# Altium schematic sheet Coheat_Thermostat.SchDoc
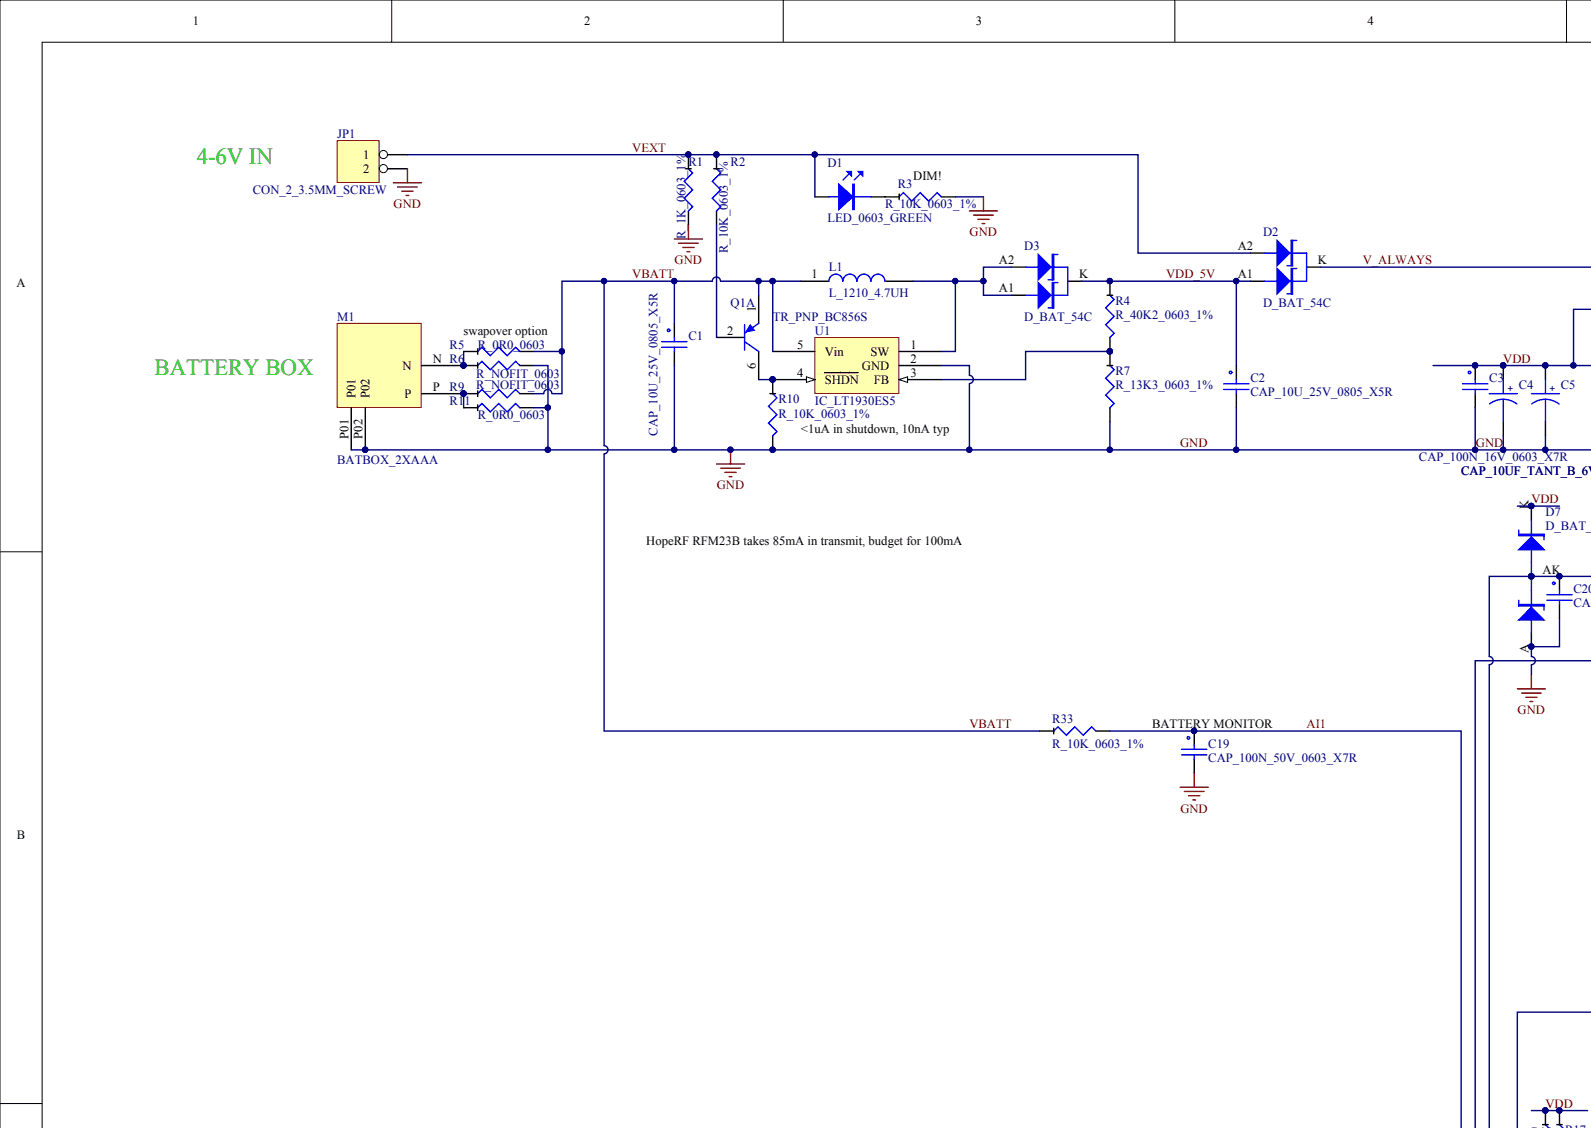
[diagram p0: Coheat_Thermostat.SchDoc, top left]
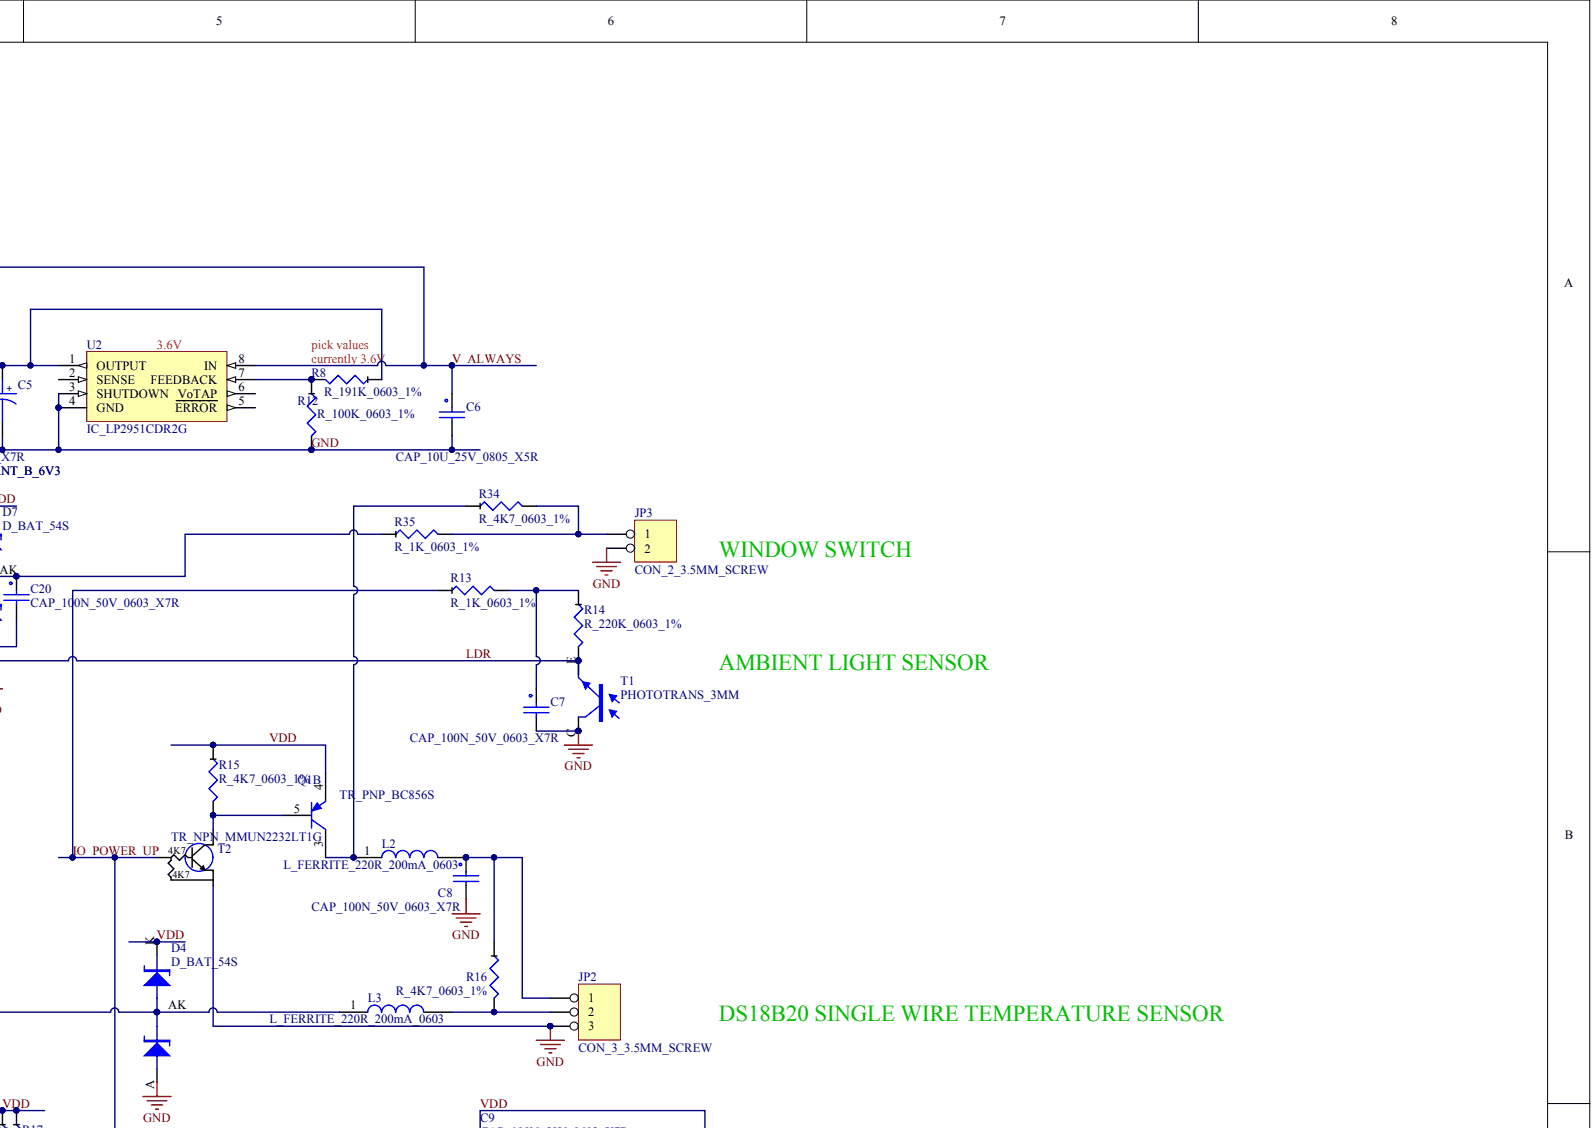
[diagram p1: Coheat_Thermostat.SchDoc, top right]
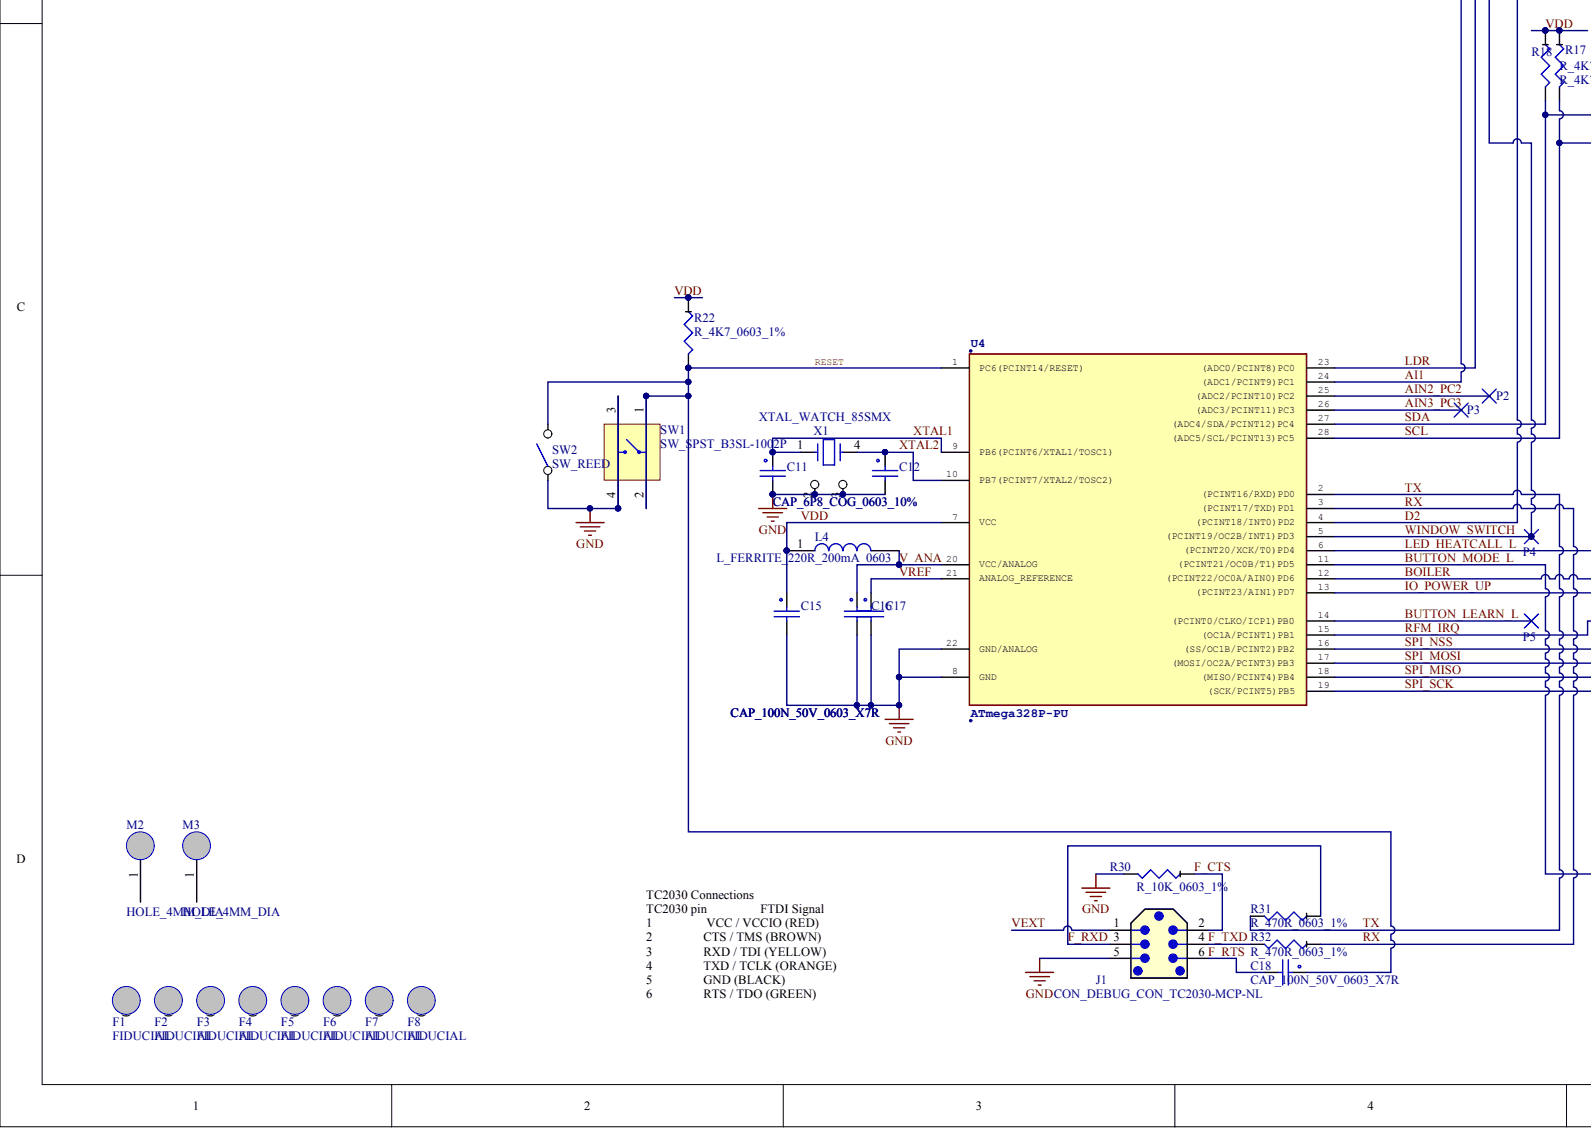
[diagram p2: Coheat_Thermostat.SchDoc, bottom left]
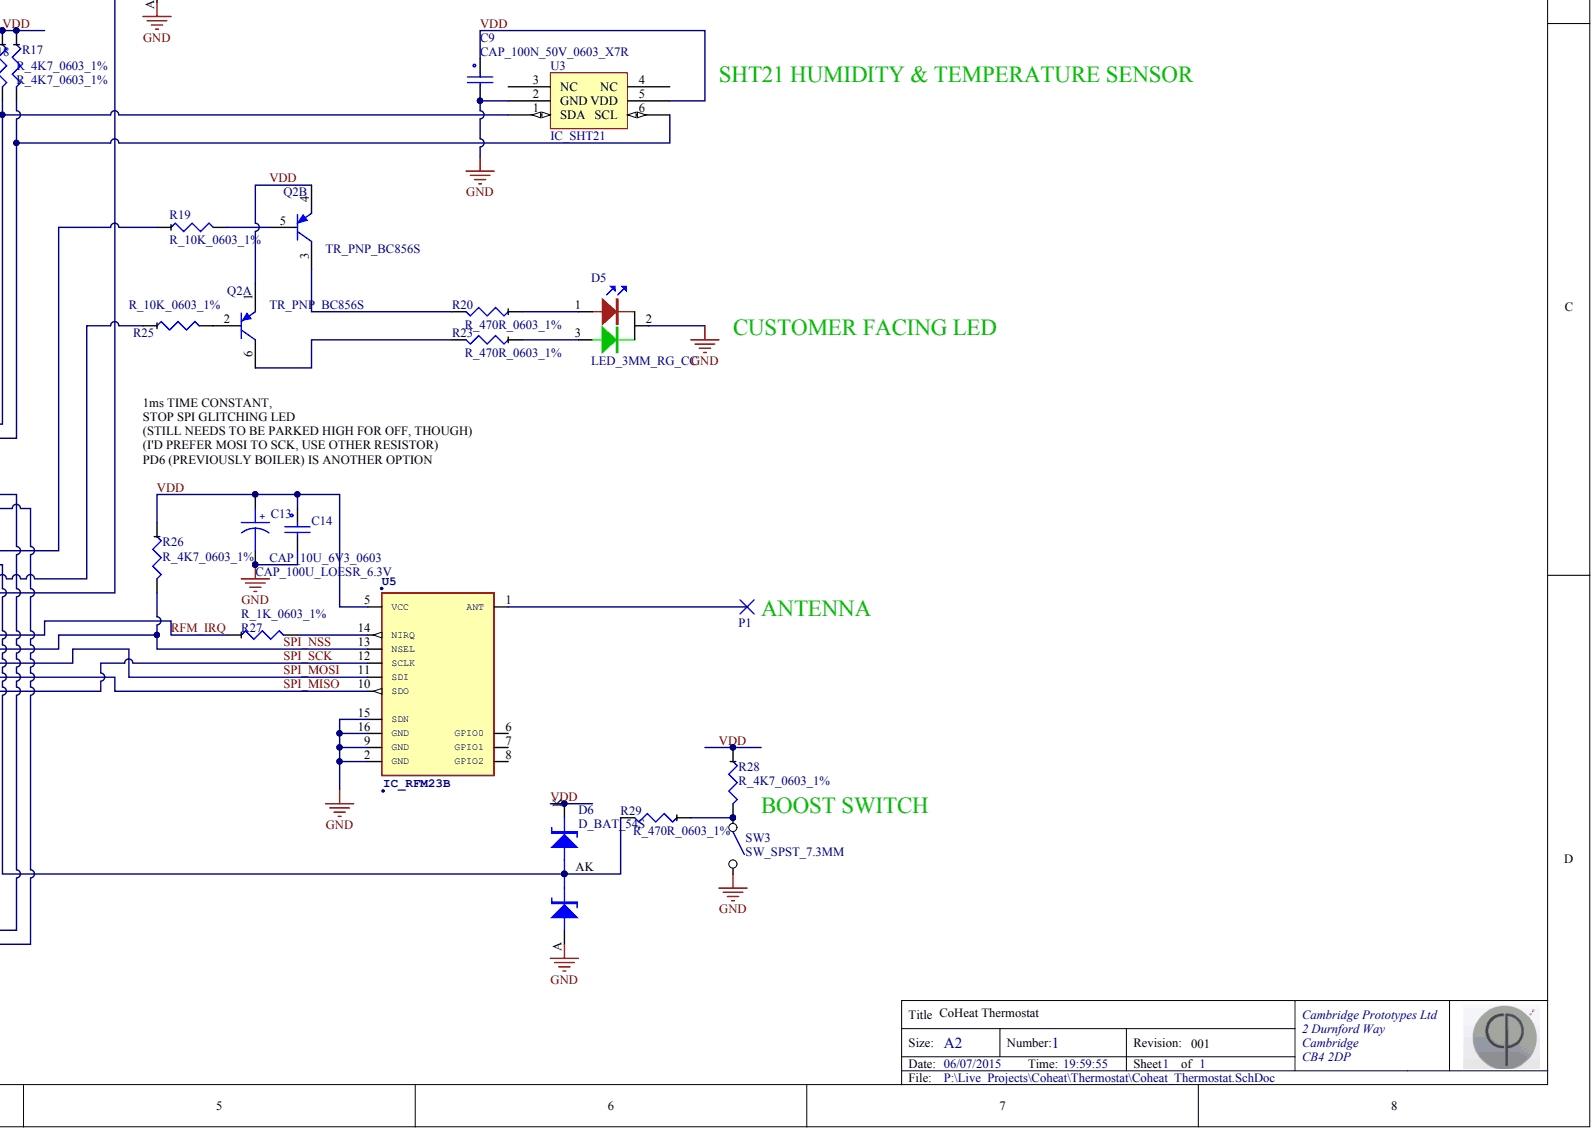
[diagram p3: Coheat_Thermostat.SchDoc, bottom right]

## Parts
C1  CAP_10U_25V_0805_X5R  [C_0805]
C2  CAP_10U_25V_0805_X5R  [C_0805]
C3  CAP_100N_16V_0603_X7R  [C_0603]
C4  CAP_10UF_TANT_B_6V3  [TANT_B]
C5  CAP_10UF_TANT_B_6V3  [TANT_B]
C6  CAP_10U_25V_0805_X5R  [C_0805]
C7  CAP_100N_50V_0603_X7R  [C_0603]
C8  CAP_100N_50V_0603_X7R  [C_0603]
C9  CAP_100N_50V_0603_X7R  [C_0603]
C11  CAP_6P8_COG_0603_10%  [C_0603]
C12  CAP_6P8_COG_0603_10%  [C_0603]
C13  CAP_100U_LOESR_6.3V  [C_2917_1.9MM]
C14  CAP_10U_6V3_0603  [C_0603]
C15  CAP_100N_50V_0603_X7R  [C_0603]
C16  CAP_100N_50V_0603_X7R  [C_0603]
C17  CAP_100N_50V_0603_X7R  [C_0603]
C18  CAP_100N_50V_0603_X7R  [C_0603]
C19  CAP_100N_50V_0603_X7R  [C_0603]
C20  CAP_100N_50V_0603_X7R  [C_0603]
D1  LED_0603_GREEN  [LED_0603_GREEN]
D2  D_BAT_54C  [SOT23_BAT54C]
D3  D_BAT_54C  [SOT23_BAT54C]
D4  D_BAT_54S  [SOT23_BAT54S]
D5  LED_3MM_RG_CC  [LED_3MM_RG_CC]
D6  D_BAT_54S  [SOT23_BAT54S]
D7  D_BAT_54S  [SOT23_BAT54S]
F1  FIDUCIAL  [FIDUCIAL_OV]
F2  FIDUCIAL  [FIDUCIAL_OV]
F3  FIDUCIAL  [FIDUCIAL_OV]
F4  FIDUCIAL  [FIDUCIAL_OV]
F5  FIDUCIAL  [FIDUCIAL_OV]
F6  FIDUCIAL  [FIDUCIAL_OV]
F7  FIDUCIAL  [FIDUCIAL_OV]
F8  FIDUCIAL  [FIDUCIAL_OV]
J1  CON_DEBUG_CON_TC2030-MCP-NL  [CON_TC2030-MCP-NL]  (value not drawn)  (no BOM)
JP1  CON_2_3.5MM_SCREW  [CON_CTB0305/2]
JP2  CON_3_3.5MM_SCREW  [CON_CTB0305/3]
JP3  CON_2_3.5MM_SCREW  [CON_CTB0305/2]
L1  L_1210_4.7UH  [L_1210]
L2  L_FERRITE_220R_200mA_0603  [L_0603]
L3  L_FERRITE_220R_200mA_0603  [L_0603]
L4  L_FERRITE_220R_200mA_0603  [L_0603]
M1  BATBOX_2XAAA  [BATBOX_2AAA]
M2  HOLE_4MM_DIA  [HOLE_4MM_DIA]  (no BOM)
M3  HOLE_4MM_DIA  [HOLE_4MM_DIA]  (no BOM)
P1  TP  [TPA_2MM]  (value not drawn)  (no BOM)
P2  TP  [TPT]  (value not drawn)  (no BOM)
P3  TP  [TPT]  (value not drawn)  (no BOM)
P4  TP  [TPT]  (value not drawn)  (no BOM)
P5  TP  [TPT]  (value not drawn)  (no BOM)
Q1  TR_PNP_BC856S  [SOT363_N]  (parts A,B)
Q2  TR_PNP_BC856S  [SOT363_N]  (parts A,B)
R1  R_1K_0603_1%  [R_0603]
R2  R_10K_0603_1%  [R_0603]
R3  R_10K_0603_1%  [R_0603]
R4  R_40K2_0603_1%  [R_0603]
R5  R_0R0_0603  [R_0603]
R6  R_NOFIT_0603  [R_0603]
R7  R_13K3_0603_1%  [R_0603]
R8  R_191K_0603_1%  [R_0603]
R9  R_NOFIT_0603  [R_0603]
R10  R_10K_0603_1%  [R_0603]
R11  R_0R0_0603  [R_0603]
R12  R_100K_0603_1%  [R_0603]
R13  R_1K_0603_1%  [R_0603]
R14  R_220K_0603_1%  [R_0603]
R15  R_4K7_0603_1%  [R_0603]
R16  R_4K7_0603_1%  [R_0603]
R17  R_4K7_0603_1%  [R_0603]
R18  R_4K7_0603_1%  [R_0603]
R19  R_10K_0603_1%  [R_0603]
R20  R_470R_0603_1%  [R_0603]
R22  R_4K7_0603_1%  [R_0603]
R23  R_470R_0603_1%  [R_0603]
R25  R_10K_0603_1%  [R_0603]
R26  R_4K7_0603_1%  [R_0603]
R27  R_1K_0603_1%  [R_0603]
R28  R_4K7_0603_1%  [R_0603]
R29  R_470R_0603_1%  [R_0603]
R30  R_10K_0603_1%  [R_0603]
R31  R_470R_0603_1%  [R_0603]
R32  R_470R_0603_1%  [R_0603]
R33  R_10K_0603_1%  [R_0603]
R34  R_4K7_0603_1%  [R_0603]
R35  R_1K_0603_1%  [R_0603]
SW1  SW_SPST_B3SL-1002P  [SW_B3SL-1002P]
SW2  SW_REED  [SW_REED_MN2308]
SW3  SW_SPST_7.3MM  [SW_MCDTS2-4N]
T1  PHOTOTRANS_3MM  [PD_3MM]
T2  TR_NPN_MMUN2232LT1G  [SOT23_NPN_BC846]
U1  IC_LT1930ES5  [SOT23_5_BASE]
U2  IC_LP2951CDR2G  [IC_SO8]
U3  IC_SHT21  PART: SHT21  [IC_DFN6_HTU21D]
U4  ATmega328P-PU  [IC_DIP28_SOCKETED]
U5  IC_RFM23B  [IC_RFM23-XXX-S1]
X1  XTAL_WATCH_85SMX  [XTAL_86SMX]

## Nets
AI1: C19.1 R33.2 U4.24((ADC1/PCINT9)PC1)
AIN2_PC2: P2.1 U4.25((ADC2/PCINT10)PC2)
AIN3_PC3: P3.1 U4.26((ADC3/PCINT11)PC3)
BOILER: R25.1 U4.12((PCINT22/OC0A/AIN0)PD6)
BUTTON_LEARN_L: P5.1 U4.14((PCINT0/CLKO/ICP1)PB0)
BUTTON_MODE_L: D6.AK R29.2 U4.11((PCINT21/OC0B/T1)PD5)
D2: D4.AK L3.1 U4.4((PCINT18/INT0)PD2)
F_CTS: J1.2 R30.1
F_RTS: C18.2 J1.6
F_RXD: J1.3 R31.1
F_TXD: J1.4 R32.2
GND: C1.2 C2.2 C3.2 C4.N C5.N C6.2 C7.2 C8.2 C9.2 C11.2 C12.2 C13.N C14.2 C15.2 C16.2 C17.2 C19.2 C20.2 D4.A D5.2 D6.A D7.A J1.5 JP1.2 JP2.3 JP3.2 M1.P01 M1.P02 R1.2 R3.2 R6.2 R7.2 R10.2 R11.2 R12.2 R30.2 SW1.3 SW1.4 SW2.1 SW3.2 T1.C T2.E U1.2(GND) U2.3(SHUTDOWN) U2.4(GND) U3.2(GND) U4.8(GND) U4.22(GND/ANALOG) U5.2(GND) U5.9(GND) U5.15(SDN) U5.16(GND) X1.2 X1.3
IO_POWER_UP: R13.1 T2.B U4.13((PCINT23/AIN1)PD7)
LDR: R14.2 T1.E U4.23((ADC0/PCINT8)PC0)
LED_HEATCALL_L: R19.1 U4.6((PCINT20/XCK/T0)PD4)
RESET: C18.1 R22.2 SW1.1 SW1.2 SW2.2 U4.1(PC6(PCINT14/RESET))
RFM_IRQ: R27.1 U4.15((OC1A/PCINT1)PB1)
RX: R32.1 U4.3((PCINT17/TXD)PD1)
SCL: R17.2 U3.6(SCL) U4.28((ADC5/SCL/PCINT13)PC5)
SDA: R18.2 U3.1(SDA) U4.27((ADC4/SDA/PCINT12)PC4)
SPI_MISO: U4.18((MISO/PCINT4)PB4) U5.10(SDO)
SPI_MOSI: U4.17((MOSI/OC2A/PCINT3)PB3) U5.11(SDI)
SPI_NSS: R26.2 U4.16((SS/OC1B/PCINT2)PB2) U5.13(NSEL)
SPI_SCK: U4.19((SCK/PCINT5)PB5) U5.12(SCLK)
TX: R31.2 U4.2((PCINT16/RXD)PD0)
V_ALWAYS: C6.1 D2.K U2.8(IN)
V_ANA: C16.1 L4.2 U4.20(VCC/ANALOG)
VBATT: C1.1 L1.1 Q1.1 R5.2 R9.2 R33.1 U1.5(Vin)
VDD: C3.1 C4.P C5.P C9.1 C13.P C14.1 C15.1 D4.K D6.K D7.K L4.1 Q1.4 Q2.1 Q2.4 R8.1 R15.1 R17.1 R18.1 R22.1 R26.1 R28.1 U2.1(OUTPUT) U3.5(VDD) U4.7(VCC) U5.5(VCC)
VDD_5V: C2.1 D2.A1 D3.K R4.1
VEXT: D1.A D2.A2 J1.1 JP1.1 R1.1 R2.1
VREF: C17.1 U4.21(ANALOG_REFERENCE)
WINDOW_SWITCH: C20.1 D7.AK P4.1 R35.1 U4.5((PCINT19/OC2B/INT1)PD3)
XTAL1: C11.1 U4.9(PB6(PCINT6/XTAL1/TOSC1)) X1.1
XTAL2: C12.1 U4.10(PB7(PCINT7/XTAL2/TOSC2)) X1.4
NetC7_1: C7.1 R13.2 R14.1
NetC8_1: C8.1 JP2.1 L2.2 R16.1
NetD1_K: D1.K R3.1
NetD3_A1: D3.A1 D3.A2 L1.2 U1.1(SW)
NetD5_1: D5.1 R20.1
NetD5_3: D5.3 R23.1
NetJP2_2: JP2.2 L3.2 R16.2
NetJP3_1: JP3.1 R34.2 R35.2
NetL2_1: L2.1 Q1.3 R34.1
NetM1_N: M1.N R5.1 R6.1
NetM1_P: M1.P R9.1 R11.1
NetP1_1: P1.1 U5.1(ANT)
NetQ1_2: Q1.2 R2.2
NetQ1_5: Q1.5 R15.2 T2.C
NetQ1_6: Q1.6 R10.1 U1.4(SHDN)
NetQ2_2: Q2.2 R25.2
NetQ2_3: Q2.3 R20.2
NetQ2_5: Q2.5 R19.2
NetQ2_6: Q2.6 R23.2
NetR4_2: R4.2 R7.1 U1.3(FB)
NetR8_2: R8.2 R12.1 U2.7(FEEDBACK)
NetR27_2: R27.2 U5.14(NIRQ)
NetR28_2: R28.2 R29.1 SW3.1

Not connected: F1 0; F2 0; F3 0; F4 0; F5 0; F6 0; F7 0; F8 0; M2 1; M3 1; U2 2(SENSE) 5(ERROR) 6(VoTAP); U3 3(NC) 4(NC); U5 6(GPIO0) 7(GPIO1) 8(GPIO2)

## Library data of the parts
C1 C2 C6 = CAP_10U_25V_0805_X5R: MANUFACTURER: MURATA; PART: GRM21BR61E106KA73L; Component Kind: Standard; Description: CAP_10U_25V_0805_X5R; FARNELL: 1735530RL; Library Name: Arbury_C.SchLib
C3 = CAP_100N_16V_0603_X7R: Text Field1: vnca65; Text Field2: 432-210; Text Field3: Yes; Text Field4: 500-038-00-00-CAP*-BP; Component Kind: Standard; Description: CAP_100N_16V_0603_X7R; FARNELL: 1650834; Library Name: Arbury_C.SchLib
C4 C5 = CAP_10UF_TANT_B_6V3: CAP_10U_TANT_6V3; Text Field1: 893-857; Text Field2: 197427; Component Kind: Standard; Library Name: Arbury_C.SchLib
C11 C12 = CAP_6P8_COG_0603_10%: Text Field1: 8819807; Text Field2: vnca100; Component Kind: Standard; Description: CAP_6P8_COG_0603_10%; Library Name: Arbury_C.SchLib
C13 = CAP_100U_LOESR_6.3V: CAP, ALU POLY 100UF, 6.3V, SMD; MANUFACTURER: PANASONIC; PART: EEFCX0J101R; Component Kind: Standard; FARNELL: 1751911RL; Library Name: Arbury_C.SchLib
C14 = CAP_10U_6V3_0603: CAP CER 10UF 6.3V 20% X5R 0603; MANUFACTURER: MURATA; PART: GRM188R60J106ME47D; Component Kind: Standard; DIGIKEY: 490-3896-6-ND; Library Name: Arbury_C.SchLib
D2 D3 = D_BAT_54C: SMD Diode Dual Common_C; MANUFACTURER: GENERIC; PART: BAT54C; Component Kind: Standard; FARNELL: 1621834; Library Name: Arbury_SCH.SchLib
D4 D7 = D_BAT_54S: SMD Diode Dual Series; MANUFACTURER: GENERIC; PART: BAT54S; Text Field1: vndi03; Text Field2: 1081195; Text Field3: vndi61; Text Field4: Yes; Text Field5: 500-761-00-00-DIOD-BP; Component Kind: Standard; FARNELL: 1467519; Library Name: Arbury_SCH.SchLib
D5 = LED_3MM_RG_CC: High Brightness Tricolor PLCC6 Black Surface LED; MANUFACTURER: KINGBRIGHT; PART: L-93WEGW; CPC: SC07621; Component Kind: Standard; FARNELL: 1142520; Library Name: Arbury_SCH.SchLib
D6 = D_BAT54S: SMD Diode Dual Series; MANUFACTURER: GENERIC; PART: BAT54S; Text Field1: vndi03; Text Field2: 1081195; Text Field3: vndi61; Text Field4: Yes; Text Field5: 500-761-00-00-DIOD-BP; Component Kind: Standard; FARNELL: 1467519; Library Name: Arbury_SCH.SchLib
F1-F8 = FIDUCIAL: FIDUCIAL - NO CONNECT; Text Field1: NOT A PART; Component Kind: Standard; Library Name: Arbury_SCH.SchLib
J1 = CON_TC2030-MCP-NL: TC2030 PROG CONNECTOR DNP; Library Name: Arbury_CON.SchLib
JP1 JP3 = CON_2_3.5MM_SCREW: 2 PIN power connector 3.5MM_SCREW; MANUFACTURER: CAMDEN BOSS; PART: CTB0305/2; Component Kind: Standard; FARNELL: 3882639; Library Name: Arbury_CON.SchLib
JP2 = CON_3_3.5MM_SCREW: 3 PIN power connector 3.5MM_SCREW; MANUFACTURER: CAMDEN BOSS; PART: CTB0305/3; Component Kind: Standard; FARNELL: 3882640; Library Name: Arbury_CON.SchLib
L1 = L_1210_4.7UH: L_2220_15UH 1.5R 220mA 50MHz; MANUFACTURER: TDK; PART: NLV32T-4R7J-PF; Component Kind: Standard; FARNELL: 1669949RL; Library Name: Arbury_SCH.SchLib
L2-L4 = L_FERRITE_220R_200mA_0603: 220R 200mA 0603 FERRITE - MURATA BLM18BB221SN1D; RS: 109-431; Component Kind: Standard; FARNELL: 1515695; MANUFACTURER: MURATA; PART: BLM18BB221SN1D; Library Name: Arbury_SCH.SchLib
M1 = BATBOX_2XAAA: KEYSTONE - 2479 - BATTERY HOLDER, AAA, 2WAY; PART: 2468; Component Kind: Standard; FARNELL: 1650679; MANUFACTURER: KEYSTONE; Library Name: Arbury_SCH.SchLib
M2 M3 = HOLE_4MM_DIA: HOLE, 4MM DIA FINISHED; Library Name: Arbury_SCH.SchLib
P1-P5 = TP: TEST POINT, NOFIT; Library Name: Arbury_SCH.SchLib
Q1 Q2 = TR_PNP_BC856S: PNP General-purpose Double Transistor; RS: 6900098; Code_IPC: SOT363-6; Component Kind: Standard; PackageReference: SOT363; Library Name: Arbury_SCH.SchLib
R1 R13 R27 R35 = R_1K_0603_1%: Text Field1: vnr39; Text Field2: 9330380; Text Field3: Yes; Text Field4: 501-218-00-00-RES*-BP; Component Kind: Standard; Description: R_1K_0603_1%; FARNELL: 9330380RL; Library Name: Arbury_R.SchLib
R2 R3 R10 R19 R25 R30 R33 = R_10K_0603_1%: Text Field1: vnr38; Text Field2: 9330399; Text Field3: Yes; Text Field4: 500-055-00-00-RES*-BP; Component Kind: Standard; Description: R_10K_0603_1%; FARNELL: 9330399RL; Library Name: Arbury_R.SchLib
R4 = R_40K2_0603_1%: Text Field1: 4224437; Component Kind: Standard; Description: R_40K2_0603_1%; Library Name: Arbury_R.SchLib
R5 R11 = R_0R0_0603: ZERO OHM 0603; Text Field1: vnr59; Text Field2: 9331662; Text Field3: Yes; Text Field4: 500-014-00-00-RES*-BP; Component Kind: Standard; FARNELL: 9331662; Library Name: Arbury_R.SchLib
R6 R9 = R_NOFIT_0603: NO-FIT 0603; Component Kind: Standard; Library Name: Arbury_R.SchLib
R7 = R_13K3_0603_1%: Text Field1: 4223998; Component Kind: Standard; Description: R_13K3_0603_1%; Library Name: Arbury_R.SchLib
R8 = R_191K_0603_1%: Text Field1: 4225030; Component Kind: Standard; Description: R_191K_0603_1%; Library Name: Arbury_R.SchLib
R12 = R_100K_0603_1%: Text Field1: vnr136; Text Field2: 9330402; Text Field3: Yes; Text Field4: 500-034-00-00-RES*-BP; Component Kind: Standard; Description: R_100K_0603_1%; FARNELL: 9330402RL; Library Name: Arbury_R.SchLib
R14 = R_220K_0603_1%: Text Field1: vnr92; Text Field2: 911513; Component Kind: Standard; Description: R_220K_0603_1%; Library Name: Arbury_R.SchLib
R15-R18 R22 R26 R28 R34 = R_4K7_0603_1%: Text Field1: vnr63; Text Field2: 9331247; Text Field3: Yes; Component Kind: Standard; Description: R_4K7_0603_1%; FARNELL: 1692457; Library Name: Arbury_R.SchLib
R20 R23 R29 R31 R32 = R_470R_0603_1%: Text Field1: vnr62; Text Field2: 9331239; Text Field3: Yes; Text Field4: 501-108-00-00-RES*-P; Component Kind: Standard; Description: R_470R_0603_1%; Library Name: Arbury_R.SchLib
SW1 = SW_SPST_B3SL-1002P: SPST SWITCH 6MM OMRON B3SL-1002P; Component Kind: Standard; FARNELL: 1695875; MANUFACTURER: OMRON; MOUSER: 653-B3SL-1002P; PART: B3SL-1002P; Library Name: Arbury_SCH.SchLib
SW2 = SW_REED: SMD REEED SWITCH MN2308 0.5A 200V; MANUFACTURER: CLARE; Component Kind: Standard; PART: MN2308; Library Name: Arbury_SCH.SchLib
SW3 = SW_SPST_7.3MM: MULTICOMP  MCDTS2-4N  TACTILE SWITCH, 7.3MM X 3.8 SQ, 160G; CPC: SW04101; Component Kind: Standard; FARNELL: 9471634; MANUFACTURER: MULTICOMP; PART: MCDTS2-4N; Library Name: Arbury_SCH.SchLib
T1 = PHOTOTRANS_3MM: 60 ° Visible Light Phototransistor, Through-hole 3mm Package; MANUFACTURER: Vishay; PART: TEPT4400; RS: 700-0776; Component Kind: Standard; Library Name: Arbury_SCH.SchLib
T2 = TR_NPN_MMUN2232LT1G: TR_NPN_WITH_4K7_BASE_4K7BE; MANUFACTURER: ON SEMICONDUCTOR; RS: 690-0149; Component Kind: Standard; PART: MMUN2232LT1G; Library Name: Arbury_SCH.SchLib
U1 = IC_LT1930ES5: 1A, 1.2MHz/2.2MHz, Step-Up DC/DC Converters in ThinSOT; MANUFACTURER: LTC; Component Kind: Standard; PART: LT1930ES5; Library Name: Arbury_IC.SchLib
U2 = IC_LP2951CDR2G: 100mA AUTOMOTIVE LDO WITH ENABLE & ERROR; MANUFACTURER: ON SEMICONDUCTOR; Component Kind: Standard; FARNELL: 2318523; MOUSER: 863-LP2951CDR2G; PART: LP2951CDR2G; Library Name: Arbury_IC.SchLib
U3 = IC_SHT21: Humidity and Temperature Sensor IC; MANUFACTURER: SENSIRION; RS: 786-5554; Component Kind: Standard; FARNELL: 1855468; Library Name: Arbury_IC.SchLib
U4 = IC_ATMEGA168-PU: MANUFACTURER: ATMEL; Component Kind: Standard; PART: ATMEGA328P-PU; Library Name: Arbury_IC.SchLib
U5 = IC_RFM23B: ISM TRANSCEIVER MODULE; MANUFACTURER: HOPE MICROELECTRONICS CO; PART: RFM23-868-S1; Component Kind: Standard; Library Name: Arbury_IC.SchLib
X1 = XTAL_WATCH_85SMX: 32.768KHz SM watch crystal; MANUFACTURER: IQD; PART: LFXTAL016178; Text Field1: 3160312; Component Kind: Standard; FARNELL: 2449396; Library Name: Arbury_SCH.SchLib
C7-C9 C15-C20 = CAP_100N_50V_0603_X7R: Component Kind: Standard; Description: CAP_100N_50V_0603_X7R; FARNELL: 1118182; Library Name: Arbury_C.SchLib
D1 = LED_0603_GREEN: Component Kind: Standard; Description: LED_0603_GREEN; FARNELL: 1685076RL; Library Name: Arbury_SCH.SchLib
